# Altium schematic sheet ГРЛМ.468263.003_1 Э3.SchDoc
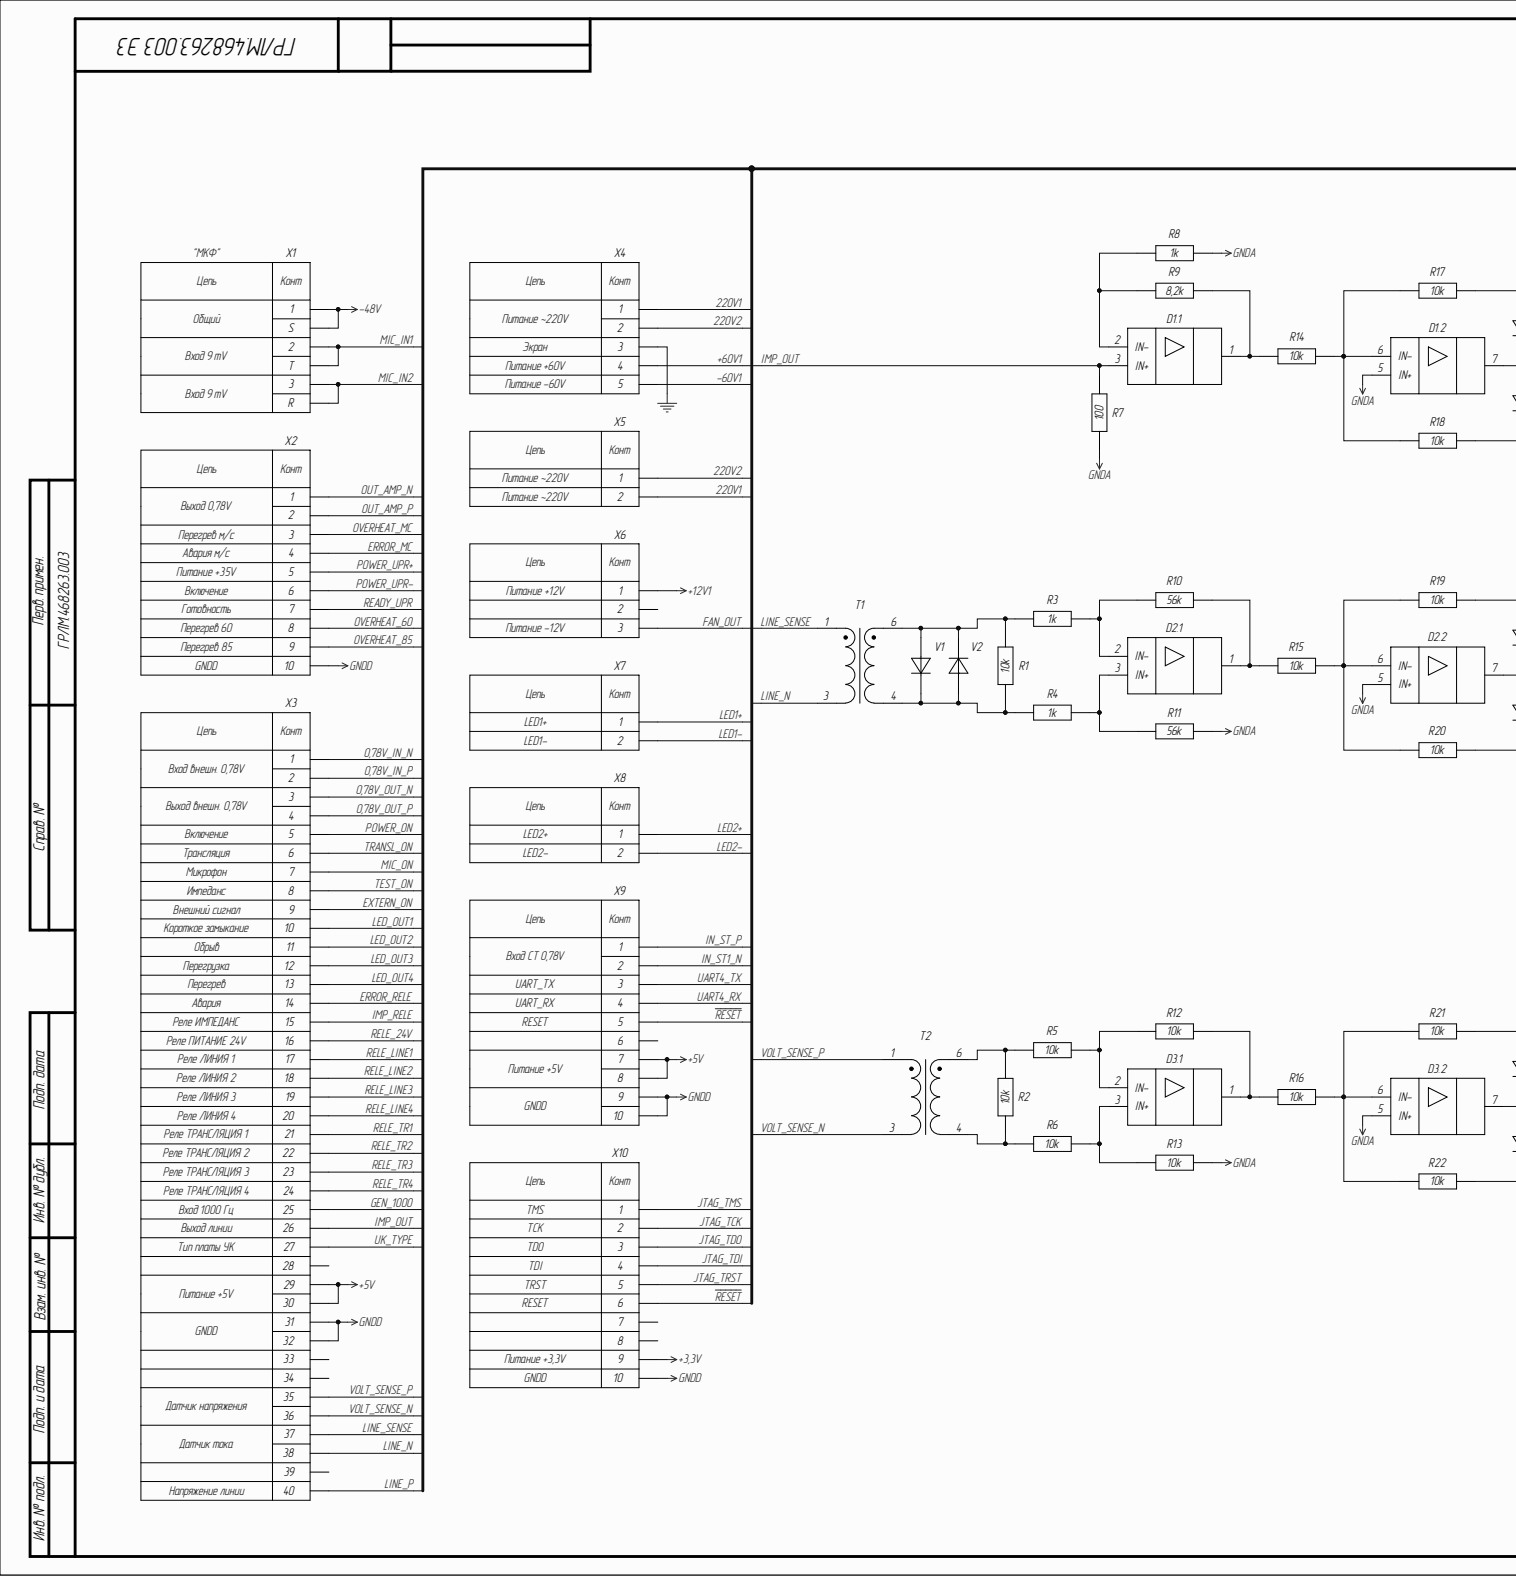
[diagram p0: ГРЛМ.468263.003_1 Э3.SchDoc, left]
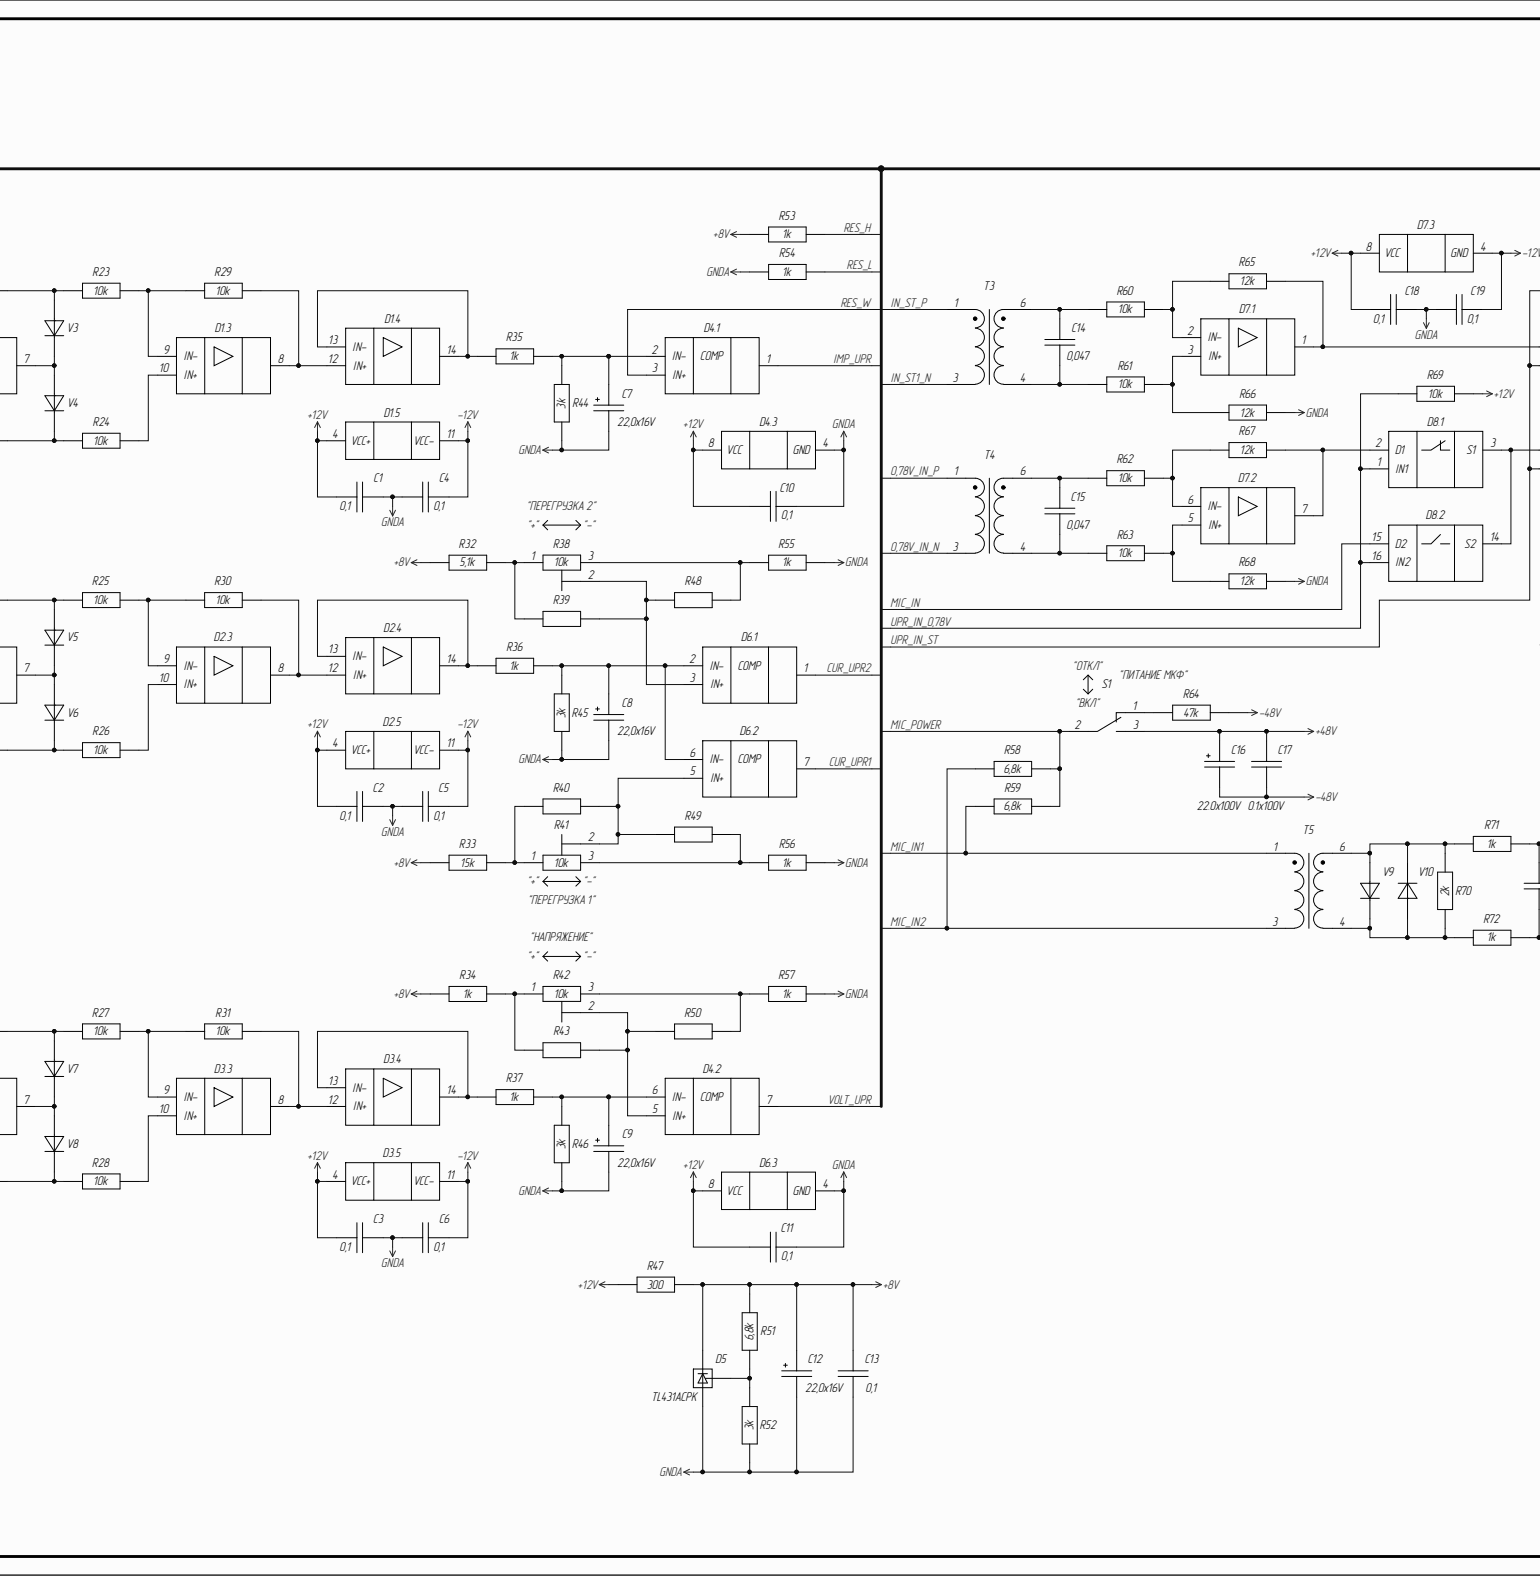
[diagram p1: ГРЛМ.468263.003_1 Э3.SchDoc, centre]
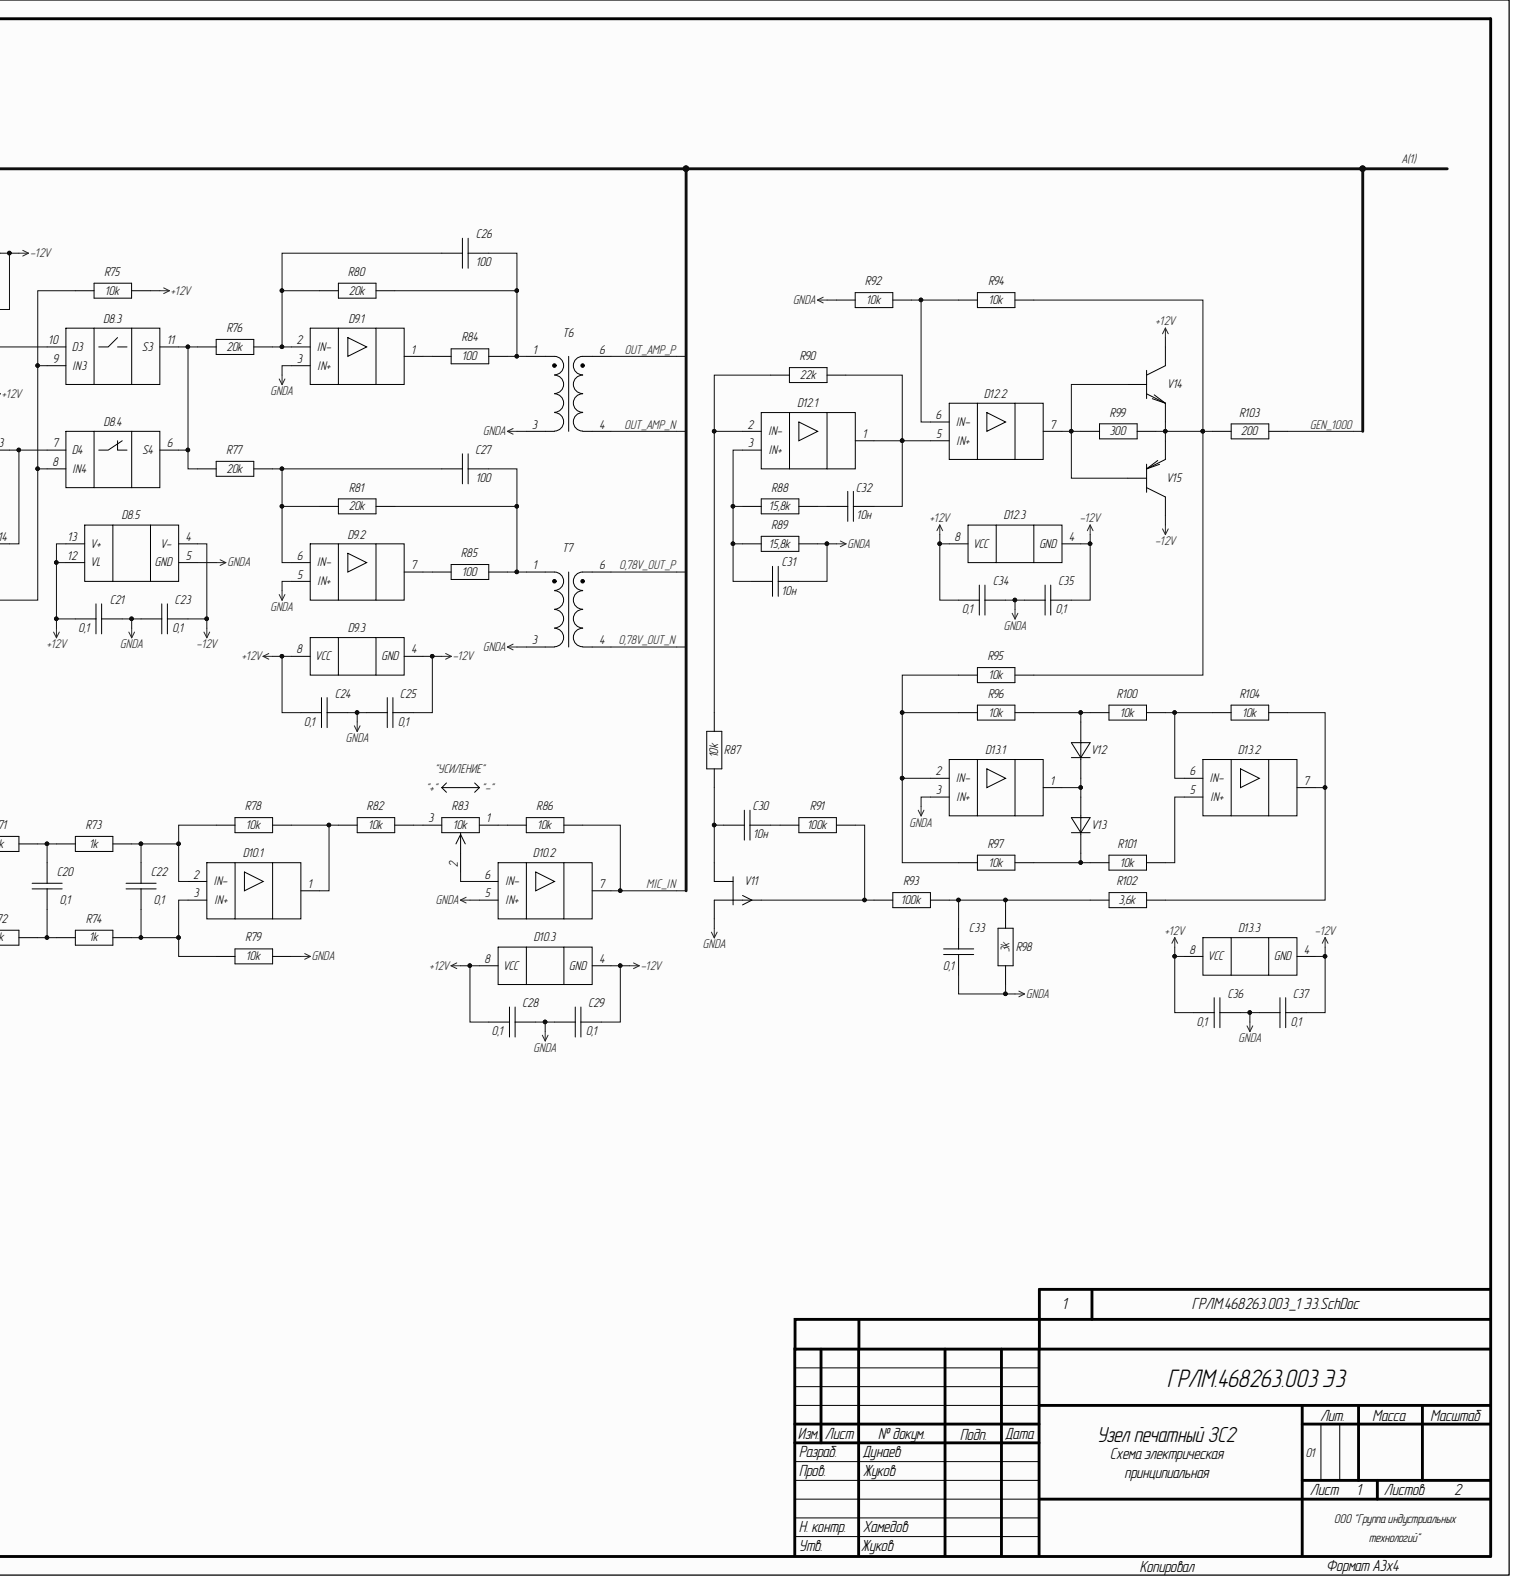
[diagram p2: ГРЛМ.468263.003_1 Э3.SchDoc, right]

## Parts
C1  value 0,1  [CAP0805]  (hidden comment: CERCAP_0805)
C2  value 0,1  [CAP0805]  (hidden comment: CERCAP_0805)
C3  value 0,1  [CAP0805]  (hidden comment: CERCAP_0805)
C4  value 0,1  [CAP0805]  (hidden comment: CERCAP_0805)
C5  value 0,1  [CAP0805]  (hidden comment: CERCAP_0805)
C6  value 0,1  [CAP0805]  (hidden comment: CERCAP_0805)
C7  value 22,0x16V  [CAP-T-B]  (hidden comment: TANCAP_B)
C8  value 22,0x16V  [CAP-T-B]  (hidden comment: TANCAP_B)
C9  value 22,0x16V  [CAP-T-B]  (hidden comment: TANCAP_B)
C10  value 0,1  [CAP0805]  (hidden comment: CERCAP_0805)
C11  value 0,1  [CAP0805]  (hidden comment: CERCAP_0805)
C12  value 22,0x16V  [CAP-T-B]  (hidden comment: TANCAP_B)
C13  value 0,1  [CAP0805]  (hidden comment: CERCAP_0805)
C14  value 0,047  [CAP0805]  (hidden comment: CERCAP_0805)
C15  value 0,047  [CAP0805]  (hidden comment: CERCAP_0805)
C16  value 22.0x100V  [B41828A9226M006]  (hidden comment: RADCAP_10x13)
C17  value 0.1х100V  [CAP1210]  (hidden comment: CERCAP_1210)
C18  value 0,1  [CAP0805]  (hidden comment: CERCAP_0805)
C19  value 0,1  [CAP0805]  (hidden comment: CERCAP_0805)
C20  value 0,1  [CAP0805]  (hidden comment: CERCAP_0805)
C21  value 0,1  [CAP0805]  (hidden comment: CERCAP_0805)
C22  value 0,1  [CAP0805]  (hidden comment: CERCAP_0805)
C23  value 0,1  [CAP0805]  (hidden comment: CERCAP_0805)
C24  value 0,1  [CAP0805]  (hidden comment: CERCAP_0805)
C25  value 0,1  [CAP0805]  (hidden comment: CERCAP_0805)
C26  value 100  [CAP0805]  (hidden comment: CERCAP_0805)
C27  value 100  [CAP0805]  (hidden comment: CERCAP_0805)
C28  value 0,1  [CAP0805]  (hidden comment: CERCAP_0805)
C29  value 0,1  [CAP0805]  (hidden comment: CERCAP_0805)
C30  value 10н  [CAP1206]  (hidden comment: CERCAP_1206)
C31  value 10н  [CAP1206]  (hidden comment: CERCAP_1206)
C32  value 10н  [CAP1206]  (hidden comment: CERCAP_1206)
C33  value 0,1  [CAP1206]  (hidden comment: CERCAP_1206)
C34  value 0,1  [CAP0805]  (hidden comment: CERCAP_0805)
C35  value 0,1  [CAP0805]  (hidden comment: CERCAP_0805)
C36  value 0,1  [CAP0805]  (hidden comment: CERCAP_0805)
C37  value 0,1  [CAP0805]  (hidden comment: CERCAP_0805)
D1  TL074IDR  [TL074IDR]  (value not drawn)  (parts .1,.2,.3,.4,.5)
D2  TL074IDR  [TL074IDR]  (value not drawn)  (parts .1,.2,.3,.4,.5)
D3  TL074IDR  [TL074IDR]  (value not drawn)  (parts .1,.2,.3,.4,.5)
D4  LM2903D  [LM2903D]  (value not drawn)  (parts .1,.2,.3)
D5  TL431ACPK  [TL431ACPK]
D6  LM2903D  [LM2903D]  (value not drawn)  (parts .1,.2,.3)
D7  TL072IDR  [TL072IDR]  (value not drawn)  (parts .1,.2,.3)
D8  ADG413BRZ  [ADG413BRZ]  (value not drawn)  (parts .1,.2,.3,.4,.5)
D9  TL072IDR  [TL072IDR]  (value not drawn)  (parts .1,.2,.3)
D10  TL072IDR  [TL072IDR]  (value not drawn)  (parts .1,.2,.3)
D12  SA5532D  [SA5532D]  (value not drawn)  (parts .1,.2,.3)
D13  TL072IDR  [TL072IDR]  (value not drawn)  (parts .1,.2,.3)
R1  value 10k  [RES0805]  (hidden comment: RES_0805)
R2  value 10k  [RES0805]  (hidden comment: RES_0805)
R3  value 1k  [RES0805]  (hidden comment: RES_0805)
R4  value 1k  [RES0805]  (hidden comment: RES_0805)
R5  value 10k  [RES0805]  (hidden comment: RES_0805)
R6  value 10k  [RES0805]  (hidden comment: RES_0805)
R7  value 100  [RES1206]  (hidden comment: RES_1206)
R8  value 1k  [RES0805]  (hidden comment: RES_0805)
R9  value 8,2k  [RES0805]  (hidden comment: RES_0805)
R10  value 56k  [RES0805]  (hidden comment: RES_0805)
R11  value 56k  [RES0805]  (hidden comment: RES_0805)
R12  value 10k  [RES0805]  (hidden comment: RES_0805)
R13  value 10k  [RES0805]  (hidden comment: RES_0805)
R14  value 10k  [RES0805]  (hidden comment: RES_0805)
R15  value 10k  [RES0805]  (hidden comment: RES_0805)
R16  value 10k  [RES0805]  (hidden comment: RES_0805)
R17  value 10k  [RES0805]  (hidden comment: RES_0805)
R18  value 10k  [RES0805]  (hidden comment: RES_0805)
R19  value 10k  [RES0805]  (hidden comment: RES_0805)
R20  value 10k  [RES0805]  (hidden comment: RES_0805)
R21  value 10k  [RES0805]  (hidden comment: RES_0805)
R22  value 10k  [RES0805]  (hidden comment: RES_0805)
R23  value 10k  [RES0805]  (hidden comment: RES_0805)
R24  value 10k  [RES0805]  (hidden comment: RES_0805)
R25  value 10k  [RES0805]  (hidden comment: RES_0805)
R26  value 10k  [RES0805]  (hidden comment: RES_0805)
R27  value 10k  [RES0805]  (hidden comment: RES_0805)
R28  value 10k  [RES0805]  (hidden comment: RES_0805)
R29  value 10k  [RES0805]  (hidden comment: RES_0805)
R30  value 10k  [RES0805]  (hidden comment: RES_0805)
R31  value 10k  [RES0805]  (hidden comment: RES_0805)
R32  value 5,1k  [RES0805]  (hidden comment: RES_0805)
R33  value 15k  [RES0805]  (hidden comment: RES_0805)
R34  value 1k  [RES0805]  (hidden comment: RES_0805)
R35  value 1k  [RES0805]  (hidden comment: RES_0805)
R36  value 1k  [RES0805]  (hidden comment: RES_0805)
R37  value 1k  [RES0805]  (hidden comment: RES_0805)
R38  value 10k  [3296W]  (hidden comment: 3296W-1)
R39  RES_0805  [RES0805]  (value not drawn)
R40  RES_0805  [RES0805]  (value not drawn)
R41  value 10k  [3296W]  (hidden comment: 3296W-1)
R42  value 10k  [3296W]  (hidden comment: 3296W-1)
R43  RES_0805  [RES0805]  (value not drawn)
R44  value 3k  [RES0805]  (hidden comment: RES_0805)
R45  value 3k  [RES0805]  (hidden comment: RES_0805)
R46  value 3k  [RES0805]  (hidden comment: RES_0805)
R47  value 300  [RES1206]  (hidden comment: RES_1206)
R48  RES_0805  [RES0805]  (value not drawn)
R49  RES_0805  [RES0805]  (value not drawn)
R50  RES_0805  [RES0805]  (value not drawn)
R51  value 6,8k  [RES0805]  (hidden comment: RES_0805)
R52  value 3k  [RES0805]  (hidden comment: RES_0805)
R53  value 1k  [RES0805]  (hidden comment: RES_0805)
R54  value 1k  [RES0805]  (hidden comment: RES_0805)
R55  value 1k  [RES0805]  (hidden comment: RES_0805)
R56  value 1k  [RES0805]  (hidden comment: RES_0805)
R57  value 1k  [RES0805]  (hidden comment: RES_0805)
R58  value 6,8k  [RES0805]  (hidden comment: RES_0805)
R59  value 6,8k  [RES0805]  (hidden comment: RES_0805)
R60  value 10k  [RES0805]  (hidden comment: RES_0805)
R61  value 10k  [RES0805]  (hidden comment: RES_0805)
R62  value 10k  [RES0805]  (hidden comment: RES_0805)
R63  value 10k  [RES0805]  (hidden comment: RES_0805)
R64  value 47k  [RES0805]  (hidden comment: RES_0805)
R65  value 12k  [RES0805]  (hidden comment: RES_0805)
R66  value 12k  [RES0805]  (hidden comment: RES_0805)
R67  value 12k  [RES0805]  (hidden comment: RES_0805)
R68  value 12k  [RES0805]  (hidden comment: RES_0805)
R69  value 10k  [RES0805]  (hidden comment: RES_0805)
R70  value 2k  [RES0805]  (hidden comment: RES_0805)
R71  value 1k  [RES0805]  (hidden comment: RES_0805)
R72  value 1k  [RES0805]  (hidden comment: RES_0805)
R73  value 1k  [RES0805]  (hidden comment: RES_0805)
R74  value 1k  [RES0805]  (hidden comment: RES_0805)
R75  value 10k  [RES0805]  (hidden comment: RES_0805)
R76  value 20k  [RES0805]  (hidden comment: RES_0805)
R77  value 20k  [RES0805]  (hidden comment: RES_0805)
R78  value 10k  [RES0805]  (hidden comment: RES_0805)
R79  value 10k  [RES0805]  (hidden comment: RES_0805)
R80  value 20k  [RES0805]  (hidden comment: RES_0805)
R81  value 20k  [RES0805]  (hidden comment: RES_0805)
R82  value 10k  [RES0805]  (hidden comment: RES_0805)
R83  value 10k  [R0901N-A10K L-25KQ/H:10]  (hidden comment: R0901N-A10K-L25KQ)
R84  value 100  [RES0805]  (hidden comment: RES_0805)
R85  value 100  [RES0805]  (hidden comment: RES_0805)
R86  value 10k  [RES0805]  (hidden comment: RES_0805)
R87  value 10k  [RES0805]  (hidden comment: RES_0805)
R88  value 15,8k  [RES0805]  (hidden comment: RES_0805)
R89  value 15,8k  [RES0805]  (hidden comment: RES_0805)
R90  value 22k  [RES0805]  (hidden comment: RES_0805)
R91  value 100k  [RES0805]  (hidden comment: RES_0805)
R92  value 10k  [RES0805]  (hidden comment: RES_0805)
R93  value 100k  [RES0805]  (hidden comment: RES_0805)
R94  value 10k  [RES0805]  (hidden comment: RES_0805)
R95  value 10k  [RES0805]  (hidden comment: RES_0805)
R96  value 10k  [RES0805]  (hidden comment: RES_0805)
R97  value 10k  [RES0805]  (hidden comment: RES_0805)
R98  value 3k  [RES0805]  (hidden comment: RES_0805)
R99  value 300  [RES0805]  (hidden comment: RES_0805)
R100  value 10k  [RES0805]  (hidden comment: RES_0805)
R101  value 10k  [RES0805]  (hidden comment: RES_0805)
R102  value 3,6k  [RES0805]  (hidden comment: RES_0805)
R103  value 200  [RES1206]  (hidden comment: RES_1206)
R104  value 10k  [RES0805]  (hidden comment: RES_0805)
S1  KLS7-SS-12F19-G5  [KLS7-SS-12F19-G5]  (value not drawn)
T1  SM-LP-5001  [SM-LP-5001]  (value not drawn)
T2  SM-LP-5001  [SM-LP-5001]  (value not drawn)
T3  SM-LP-5001  [SM-LP-5001]  (value not drawn)
T4  SM-LP-5001  [SM-LP-5001]  (value not drawn)
T5  SM-LP-5001  [SM-LP-5001]  (value not drawn)
T6  SM-LP-5001  [SM-LP-5001]  (value not drawn)
T7  SM-LP-5001  [SM-LP-5001]  (value not drawn)
V1  LL4148  [LL4148]  (value not drawn)
V2  LL4148  [LL4148]  (value not drawn)
V3  LL4148  [LL4148]  (value not drawn)
V4  LL4148  [LL4148]  (value not drawn)
V5  LL4148  [LL4148]  (value not drawn)
V6  LL4148  [LL4148]  (value not drawn)
V7  LL4148  [LL4148]  (value not drawn)
V8  LL4148  [LL4148]  (value not drawn)
V9  LL4148  [LL4148]  (value not drawn)
V10  LL4148  [LL4148]  (value not drawn)
V11  MMBFJ177  [MMBFJ177]  (value not drawn)
V12  LL4148  [LL4148]  (value not drawn)
V13  LL4148  [LL4148]  (value not drawn)
V14  BC817  [BC817-40.215]  (value not drawn)
V15  BC807  [BC807-40.215]  (value not drawn)
X1  NCJ6FA-H  [NCJ6FA-H]  (value not drawn)
X2  FDC-10  [FDC-10]  (value not drawn)
X3  IDC-40MS  [IDC-40MS]  (value not drawn)
X4  PWL2-05 (B5P-VH-B)  [PWL2-05]  (value not drawn)
X5  PWL2-02 (B2P-VH-B)  [PWL2-02]  (value not drawn)
X6  CWF-3  [CWF-3]  (value not drawn)
X7  CWF-2  [CWF-2]  (value not drawn)
X8  CWF-2  [CWF-2]  (value not drawn)
X9  IDC-10MS  [IDC-10MS]  (value not drawn)
X10  IDC-10MS  [IDC-10MS]  (value not drawn)

## Nets
+3,3V: X10.9
+5V: X3.29 X3.30 X9.7 X9.8
+8V: C12.1 C13.2 D5.3 R32.1 R33.1 R34.1 R47.2 R51.1 R53.1
+12V: C1.1 C2.1 C3.1 C10.1 C11.1 C18.1 C21.1 C24.1 C28.1 C34.1 C36.1 D1.4(VCC+) D2.4(VCC+) D3.4(VCC+) D4.8(VCC) D6.8(VCC) D7.8(VCC) D8.12(VL) D8.13(V+) D9.8(VCC) D10.8(VCC) D12.8(VCC) D13.8(VCC) R47.1 R69.1 R75.1 V14.3
+12V1: X6.1
+48V: C16.1 C17.2 S1.3
-12V: C4.2 C5.2 C6.2 C19.2 C23.2 C25.2 C29.2 C35.2 C37.2 D1.11(VCC-) D2.11(VCC-) D3.11(VCC-) D7.4(GND) D8.4(V-) D9.4(GND) D10.4(GND) D12.4(GND) D13.4(GND) V15.3
-48V: C16.2 C17.1 R64.2 X1.1 X1.S
GNDA: C1.2 C2.2 C3.2 C4.1 C5.1 C6.1 C7.2 C8.2 C9.2 C10.2 C11.2 C12.2 C13.1 C18.2 C19.1 C21.2 C23.1 C24.2 C25.1 C28.2 C29.1 C31.1 C33.2 C34.2 C35.1 C36.2 C37.1 D1.5(IN+) D2.5(IN+) D3.5(IN+) D4.4(GND) D5.2 D6.4(GND) D8.5(GND) D9.3(IN+) D9.5(IN+) D10.5(IN+) D13.3(IN+) R7.2 R8.1 R11.1 R13.1 R44.2 R45.2 R46.2 R52.2 R54.1 R55.2 R56.2 R57.2 R66.1 R68.1 R79.1 R89.1 R92.2 R98.1 T6.3 T7.3 V11.2
GNDD: X2.10 X3.31 X3.32 X9.9 X9.10 X10.10
SHIELD: X4.3
0,78V_IN_N: T4.3 X3.1
0,78V_IN_P: T4.1 X3.2
0,78V_OUT_N: T7.4 X3.3
0,78V_OUT_P: T7.6 X3.4
220V1: X4.1 X5.2
220V2: X4.2 X5.1
+60V1: X4.4
-60V1: X4.5
CUR_UPR1: D6.7
CUR_UPR2: D6.1
ERROR_MC: X2.4
ERROR_RELE: X3.14
EXTERN_ON: X3.9
FAN_OUT: X6.3
GEN_1000: R103.2 X3.25
IMP_OUT: D1.3(IN+) R7.1 X3.26
IMP_RELE: X3.15
IMP_UPR: D4.1
IN_ST1_N: T3.3 X9.2
IN_ST_P: T3.1 X9.1
JTAG_TCK: X10.2
JTAG_TDI: X10.4
JTAG_TDO: X10.3
JTAG_TMS: X10.1
JTAG_TRST: X10.5
LED1+: X7.1
LED1-: X7.2
LED2+: X8.1
LED2-: X8.2
LED_OUT1: X3.10
LED_OUT2: X3.11
LED_OUT3: X3.12
LED_OUT4: X3.13
LINE_N: T1.3 X3.38
LINE_P: X3.40
LINE_SENSE: T1.1 X3.37
MIC_IN: D8.15(D2) D10.7 R86.1
MIC_IN1: R59.1 T5.1 X1.2 X1.T
MIC_IN2: R58.1 T5.3 X1.3 X1.R
MIC_ON: X3.7
MIC_POWER: R58.2 R59.2 S1.2
OUT_AMP_N: T6.4 X2.1
OUT_AMP_P: T6.6 X2.2
OVERHEAT_60: X2.8
OVERHEAT_85: X2.9
OVERHEAT_MC: X2.3
POWER_ON: X3.5
POWER_UPR+: X2.5
POWER_UPR-: X2.6
~{RESET}: X9.5 X10.6
READY_UPR: X2.7
RELE_24V: X3.16
RELE_LINE1: X3.17
RELE_LINE2: X3.18
RELE_LINE3: X3.19
RELE_LINE4: X3.20
RELE_TR1: X3.21
RELE_TR2: X3.22
RELE_TR3: X3.23
RELE_TR4: X3.24
RES_H: R53.2
RES_L: R54.2
RES_W: D4.3(IN+)
TEST_ON: X3.8
TRANSL_ON: X3.6
UART4_RX: X9.4
UART4_TX: X9.3
UK_TYPE: X3.27
UPR_IN_0,78V: D8.1(IN1) D8.16(IN2) R69.2
UPR_IN_ST: D8.8(IN4) D8.9(IN3) R75.2
VOLT_SENSE_N: T2.3 X3.36
VOLT_SENSE_P: T2.1 X3.35
VOLT_UPR: D4.7
NetC7_1: C7.1 D4.2(IN-) R35.2 R44.1
NetC8_1: C8.1 D6.2(IN-) D6.6(IN-) R36.2 R45.1
NetC9_1: C9.1 D4.6(IN-) R37.2 R46.1
NetC14_1: C14.1 R60.2 T3.6
NetC14_2: C14.2 R61.2 T3.4
NetC15_1: C15.1 R62.2 T4.6
NetC15_2: C15.2 R63.2 T4.4
NetC20_1: C20.1 R71.2 R73.1
NetC20_2: C20.2 R72.2 R74.1
NetC22_1: C22.1 D10.2(IN-) R73.2 R78.2
NetC22_2: C22.2 D10.3(IN+) R74.2 R79.2
NetC26_1: C26.1 R80.1 R84.1 T6.1
NetC26_2: C26.2 D9.2(IN-) R76.1 R80.2
NetC27_1: C27.1 R81.1 R85.1 T7.1
NetC27_2: C27.2 D9.6(IN-) R77.1 R81.2
NetC30_1: C30.1 R91.2
NetC30_2: C30.2 R87.1 V11.1
NetC31_2: C31.2 D12.3(IN+) R88.2 R89.2
NetC32_1: C32.1 D12.1 D12.5(IN+) R90.1
NetC32_2: C32.2 R88.1
NetC33_1: C33.1 R93.1 R98.2 R102.1
NetD1_1: D1.1 R9.1 R14.2
NetD1_2: D1.2(IN-) R8.2 R9.2
NetD1_6: D1.6(IN-) R14.1 R17.2 R18.2
NetD1_7: D1.7 V3.1 V4.2
NetD1_8: D1.8 D1.12(IN+) R29.1
NetD1_9: D1.9(IN-) R23.1 R29.2
NetD1_10: D1.10(IN+) R24.1
NetD1_13: D1.13(IN-) D1.14 R35.1
NetD2_1: D2.1 R10.1 R15.2
NetD2_2: D2.2(IN-) R3.1 R10.2
NetD2_3: D2.3(IN+) R4.1 R11.2
NetD2_6: D2.6(IN-) R15.1 R19.2 R20.2
NetD2_7: D2.7 V5.1 V6.2
NetD2_8: D2.8 D2.12(IN+) R30.1
NetD2_9: D2.9(IN-) R25.1 R30.2
NetD2_10: D2.10(IN+) R26.1
NetD2_13: D2.13(IN-) D2.14 R36.1
NetD3_1: D3.1 R12.1 R16.2
NetD3_2: D3.2(IN-) R5.1 R12.2
NetD3_3: D3.3(IN+) R6.1 R13.2
NetD3_6: D3.6(IN-) R16.1 R21.2 R22.2
NetD3_7: D3.7 V7.1 V8.2
NetD3_8: D3.8 D3.12(IN+) R31.1
NetD3_9: D3.9(IN-) R27.1 R31.2
NetD3_10: D3.10(IN+) R28.1
NetD3_13: D3.13(IN-) D3.14 R37.1
NetD4_5: D4.5(IN+) R42.2 R43.2 R50.1
NetD5_1: D5.1 R51.2 R52.1
NetD6_3: D6.3(IN+) R38.2 R39.2 R48.1
NetD6_5: D6.5(IN+) R40.2 R41.2 R49.1
NetD7_1: D7.1 D8.10(D3) R65.1
NetD7_2: D7.2(IN-) R60.1 R65.2
NetD7_3: D7.3(IN+) R61.1 R66.2
NetD7_5: D7.5(IN+) R63.1 R68.2
NetD7_6: D7.6(IN-) R62.1 R67.2
NetD7_7: D7.7 D8.2(D1) R67.1
NetD8_3: D8.3(S1) D8.7(D4) D8.14(S2)
NetD8_6: D8.6(S4) D8.11(S3) R76.2 R77.2
NetD9_1: D9.1 R84.2
NetD9_7: D9.7 R85.2
NetD10_1: D10.1 R78.1 R82.2
NetD10_6: D10.6(IN-) R83.2
NetD12_2: D12.2(IN-) R87.2 R90.2
NetD12_6: D12.6(IN-) R92.1 R94.2
NetD12_7: D12.7 R99.2 V14.1 V15.1
NetD13_1: D13.1 V12.1 V13.2
NetD13_2: D13.2(IN-) R95.2 R96.2 R97.2
NetD13_5: D13.5(IN+) R101.1
NetD13_6: D13.6(IN-) R100.1 R104.2
NetD13_7: D13.7 R102.2 R104.1
NetR1_1: R1.1 R3.2 T1.6 V1.2 V2.1
NetR1_2: R1.2 R4.2 T1.4 V1.1 V2.2
NetR2_1: R2.1 R5.2 T2.6
NetR2_2: R2.2 R6.2 T2.4
NetR17_1: R17.1 R23.2 V3.2
NetR18_1: R18.1 R24.2 V4.1
NetR19_1: R19.1 R25.2 V5.2
NetR20_1: R20.1 R26.2 V6.1
NetR21_1: R21.1 R27.2 V7.2
NetR22_1: R22.1 R28.2 V8.1
NetR32_2: R32.2 R38.1 R39.1
NetR33_2: R33.2 R40.1 R41.1
NetR34_2: R34.2 R42.1 R43.1
NetR38_3: R38.3 R48.2 R55.1
NetR41_3: R41.3 R49.2 R56.1
NetR42_3: R42.3 R50.2 R57.1
NetR64_1: R64.1 S1.1
NetR70_1: R70.1 R71.1 T5.6 V9.2 V10.1
NetR70_2: R70.2 R72.1 T5.4 V9.1 V10.2
NetR82_1: R82.1 R83.3
NetR83_1: R83.1 R86.2
NetR91_1: R91.1 R93.2 V11.3
NetR94_1: R94.1 R95.1 R99.1 R103.1 V14.2 V15.2
NetR96_1: R96.1 R100.2 V12.2
NetR97_1: R97.1 R101.2 V13.1
N00052:

Not connected: X3 28 33 34 39; X6 2; X9 6; X10 7 8

## Library data of the parts
C1-C6 C10 C11 C13 C18 C19 C21 C23-C25 C28 C29 C34-C37 = CERCAP_0805: Конденсатор керамический; Type: CC0805KPX7R9BB104; Артикул: 0805-0,1 мкФ±10%-50В-X7R; Производитель: YAGEO; Component Kind: Standard; Library Name: Конденсаторы.SchLib
C7-C9 C12 = TANCAP_B: Конденсатор танталовый; Type: TAJB226K016RNJ; Артикул: 22 мкФ±10%-16В, тип B; Производитель: AVX; Component Kind: Standard; Library Name: Конденсаторы.SchLib
C14 C15 = CERCAP_0805: Конденсатор керамический; Type: CC0805KRX7R9BB473; Артикул: 0805-0,047 мкФ±10%-50В-X7R; Производитель: YAGEO; Component Kind: Standard; Library Name: Конденсаторы.SchLib
C16 = RADCAP_10x13: Конденсатор электролитический радиальный; Артикул: 22 мкФ±20%-100В, 8x12, RM5,0; Производитель: EPCOS; Component Kind: Standard; Type: B41828A9226M006; Library Name: Конденсаторы.SchLib
C17 = CERCAP_1210: Конденсатор керамический; Type: CC1210KFX7R0BB104; Артикул: 1210-0,1 мкФ±10%-100В-X7R; Производитель: YAGEO; Component Kind: Standard; Library Name: Конденсаторы.SchLib
C20 C22 = CERCAP_0805: Конденсатор керамический; Type: CC0805KKX7R0BB104; Артикул: 0805-0,1 мкФ±10%-50В-X7R; Производитель: YAGEO; Component Kind: Standard; Library Name: Конденсаторы.SchLib
C26 C27 = CERCAP_0805: Конденсатор керамический; Type: CC0805JRNPO9BN101; Артикул: 0805-100 пФ±10%-50В-NP0; Производитель: YAGEO; Component Kind: Standard; Library Name: Конденсаторы.SchLib
C30-C32 = CERCAP_1206: Конденсатор керамический; Type: C1206C103F3GECAUTO; Артикул: 1206-0,01 мкФ±1%-25В-C0G; Производитель: KEMET; Component Kind: Standard; Library Name: Конденсаторы.SchLib
C33 = CERCAP_1206: Конденсатор керамический; Type: GRM31C5C1H104JA01L; Артикул: 1206-0,1 мкФ±5%-50В-C0G; Производитель: Murata; Component Kind: Standard; Library Name: Конденсаторы.SchLib
D1-D3 = TL074IDR: ОУ сдвоенный; Производитель: Texas Instruments; Component Kind: Standard; Library Name: Микросхемы.SchLib
D4 D6 = LM2903D: Компаратор сдвоенный; Производитель: Texas Instruments; Component Kind: Standard; Library Name: Микросхемы.SchLib
D5 = TL431ACPK: Стабилизатор; Производитель: Texas Instruments; Component Kind: Standard; Library Name: Микросхемы.SchLib
D7 D9 D10 D13 = TL072IDR: ОУ сдвоенный; Производитель: Texas Instruments; Component Kind: Standard; Library Name: Микросхемы.SchLib
D8 = ADG413BRZ: Оптоключ; Производитель: Analog Devices; Component Kind: Standard; Library Name: Микросхемы.SchLib
D12 = SA5532D: ОУ сдвоенный; Производитель: Texas Instruments; Component Kind: Standard; Library Name: Микросхемы.SchLib
R1 R2 R5 R6 R12-R31 R60-R63 R69 R75 R78 R79 R82 R86 R92 R94-R97 R100 R101 R104 = RES_0805: Резистор SMD; Артикул: 0805-10 кОм±5%; Производитель: YAGEO; Component Kind: Standard; Type: RC0805JR-0710KL; Library Name: Резисторы.SchLib
R3 R4 R8 R34-R37 R53-R57 R71-R74 = RES_0805: Резистор SMD; Артикул: 0805-1 кОм±5%; Производитель: YAGEO; Component Kind: Standard; Type: RC0805JR-071KL; Library Name: Резисторы.SchLib
R7 = RES_1206: Резистор SMD; Артикул: 1206-100 Ом±1%; Производитель: YAGEO; Component Kind: Standard; Type: RC1206FR-07100RL; Library Name: Резисторы.SchLib
R9 = RES_0805: Резистор SMD; Артикул: 0805-8,2 кОм±5%; Производитель: YAGEO; Component Kind: Standard; Type: RC0805JR-078K2L; Library Name: Резисторы.SchLib
R10 R11 = RES_0805: Резистор SMD; Артикул: 0805-56 кОм±5%; Производитель: YAGEO; Component Kind: Standard; Type: RC0805JR-0756KL; Library Name: Резисторы.SchLib
R32 = RES_0805: Резистор SMD; Артикул: 0805-5,1 кОм±5%; Производитель: YAGEO; Component Kind: Standard; Type: RC0805JR-075K1L; Library Name: Резисторы.SchLib
R33 = RES_0805: Резистор SMD; Артикул: 0805-15 кОм±5%; Производитель: YAGEO; Component Kind: Standard; Type: RC0805JR-0715KL; Library Name: Резисторы.SchLib
R38 R41 R42 = 3296W-1: Резистор подстроечный; Артикул: 10 кОм±10%; Производитель: Bourns; Component Kind: Standard; Type: 3296W-1-103LF; Library Name: Резисторы.SchLib
R39 R40 R43 R48-R50 = RES_0805: Резистор SMD; Component Kind: Standard; Value: -; Library Name: Резисторы.SchLib
R44-R46 = RES_0805: Резистор SMD; Артикул: 0805-3 кОм±5%; Производитель: YAGEO; Component Kind: Standard; Type: RC0805JR-073KL; Library Name: Резисторы.SchLib
R47 = RES_1206: Резистор SMD; Артикул: 1206-300 Ом±5%; Производитель: YAGEO; Component Kind: Standard; Type: RC1206JR-07300RL; Library Name: Резисторы.SchLib
R51 R58 R59 = RES_0805: Резистор SMD; Артикул: 0805-6,8 кОм±5%; Производитель: YAGEO; Component Kind: Standard; Type: RC0805JR-076K8L; Library Name: Резисторы.SchLib
R52 R98 = RES_0805: Резистор SMD; Артикул: 0805-3 кОм±1%; Производитель: YAGEO; Component Kind: Standard; Type: RC0805FR-073KL; Library Name: Резисторы.SchLib
R64 = RES_0805: Резистор SMD; Артикул: 0805-47 кОм±5%; Производитель: YAGEO; Component Kind: Standard; Type: RC0805JR-0747KL; Library Name: Резисторы.SchLib
R65-R68 = RES_0805: Резистор SMD; Артикул: 0805-12 кОм±5%; Производитель: YAGEO; Component Kind: Standard; Type: RC0805JR-0712KL; Library Name: Резисторы.SchLib
R70 = RES_0805: Резистор SMD; Артикул: 0805-2 кОм±5%; Производитель: YAGEO; Component Kind: Standard; Type: RC0805JR-072KL; Library Name: Резисторы.SchLib
R76 R77 R80 R81 = RES_0805: Резистор SMD; Артикул: 0805-20 кОм±5%; Производитель: YAGEO; Component Kind: Standard; Type: RC0805JR-0720KL; Library Name: Резисторы.SchLib
R83 = R0901N-A10K-L25KQ: Резистор переменный; Component Kind: Standard; Library Name: Резисторы.SchLib
R84 R85 = RES_0805: Резистор SMD; Артикул: 0805-100 Ом±5%; Производитель: YAGEO; Component Kind: Standard; Type: RC0805JR-07100RL; Library Name: Резисторы.SchLib
R87 = RES_0805: Резистор SMD; Артикул: 0805-10 кОм±1%; Производитель: YAGEO; Component Kind: Standard; Type: RC0805FR-0710KL; Library Name: Резисторы.SchLib
R88 R89 = RES_0805: Резистор SMD; Артикул: 0805-15,8 кОм±1%; Производитель: YAGEO; Component Kind: Standard; Type: RC0805FR-0715K8L; Library Name: Резисторы.SchLib
R90 = RES_0805: Резистор SMD; Артикул: 0805-22 кОм±1%; Производитель: YAGEO; Component Kind: Standard; Type: RC0805FR-0722KL; Library Name: Резисторы.SchLib
R91 R93 = RES_0805: Резистор SMD; Артикул: 0805-100 кОм±5%; Производитель: YAGEO; Component Kind: Standard; Type: RC0805JR-07100KL; Library Name: Резисторы.SchLib
R99 = RES_0805: Резистор SMD; Артикул: 0805-300 Ом±5%; Производитель: YAGEO; Component Kind: Standard; Type: RC0805JR-07300RL; Library Name: Резисторы.SchLib
R102 = RES_0805: Резистор SMD; Артикул: 0805-3,6 кОм±1%; Производитель: YAGEO; Component Kind: Standard; Type: RC0805FR-073K6L; Library Name: Резисторы.SchLib
R103 = RES_1206: Резистор SMD; Артикул: 1206-200 Ом±1%; Производитель: YAGEO; Component Kind: Standard; Type: RC1206FR-07200RL; Library Name: Резисторы.SchLib
S1 = KLS7-SS-12F19-G5: Переключатель 1-пол.; Component Kind: Standard; Library Name: Коммутационные изделия.SchLib
T1-T7 = SM-LP-5001: Трансформатор согласующий; Производитель: Bourns; Component Kind: Standard; Library Name: Индуктивные элементы.SchLib
V1-V10 V12 V13 = LL4148: Диод выпрямительный; Производитель: Vishay; Component Kind: Standard
  V1 V2 V9 V10: Library Name: ГРЛМ.468363.001 Э3.SCHLIB
  V3-V8 V12 V13: Library Name: Полупроводники.SchLib
V11 = MMBFJ177: Транзистор P-канал; Производитель: ON Semiconductor; Component Kind: Standard; Library Name: Транзисторы.SchLib
V14 = BC817: Транзистор NPN; Производитель: NXP; Component Kind: Standard; Library Name: Транзисторы.SchLib
V15 = BC807: Транзистор PNP; Производитель: NXP; Component Kind: Standard; Library Name: Транзисторы.SchLib
X1 = NCJ6FA-H: Розетка; Производитель: Neutrik; Component Kind: Standard; Library Name: Соединители.SchLib
X2 = FDC-10: Вилка; Производитель: Connfly; Component Kind: Standard; Library Name: Соединители.SchLib
X3 = IDC-40MS: Вилка; Component Kind: Standard; Library Name: Соединители.SchLib
X4 = PWL2-05 (B5P-VH-B): Вилка; Производитель: JST; Component Kind: Standard; Library Name: Соединители.SchLib
X5 = PWL2-02 (B2P-VH-B): Вилка; Производитель: JST; Component Kind: Standard; Library Name: Соединители.SchLib
X6 = CWF-3: Вилка; Component Kind: Standard; Library Name: Соединители.SchLib
X7 X8 = CWF-2: Вилка; Производитель: Connfly; Component Kind: Standard; Library Name: Соединители.SchLib
X9 X10 = IDC-10MS: Вилка; Производитель: Connfly; Component Kind: Standard; Library Name: Соединители.SchLib
